# Altium schematic sheet connectors.SchDoc(connectors)
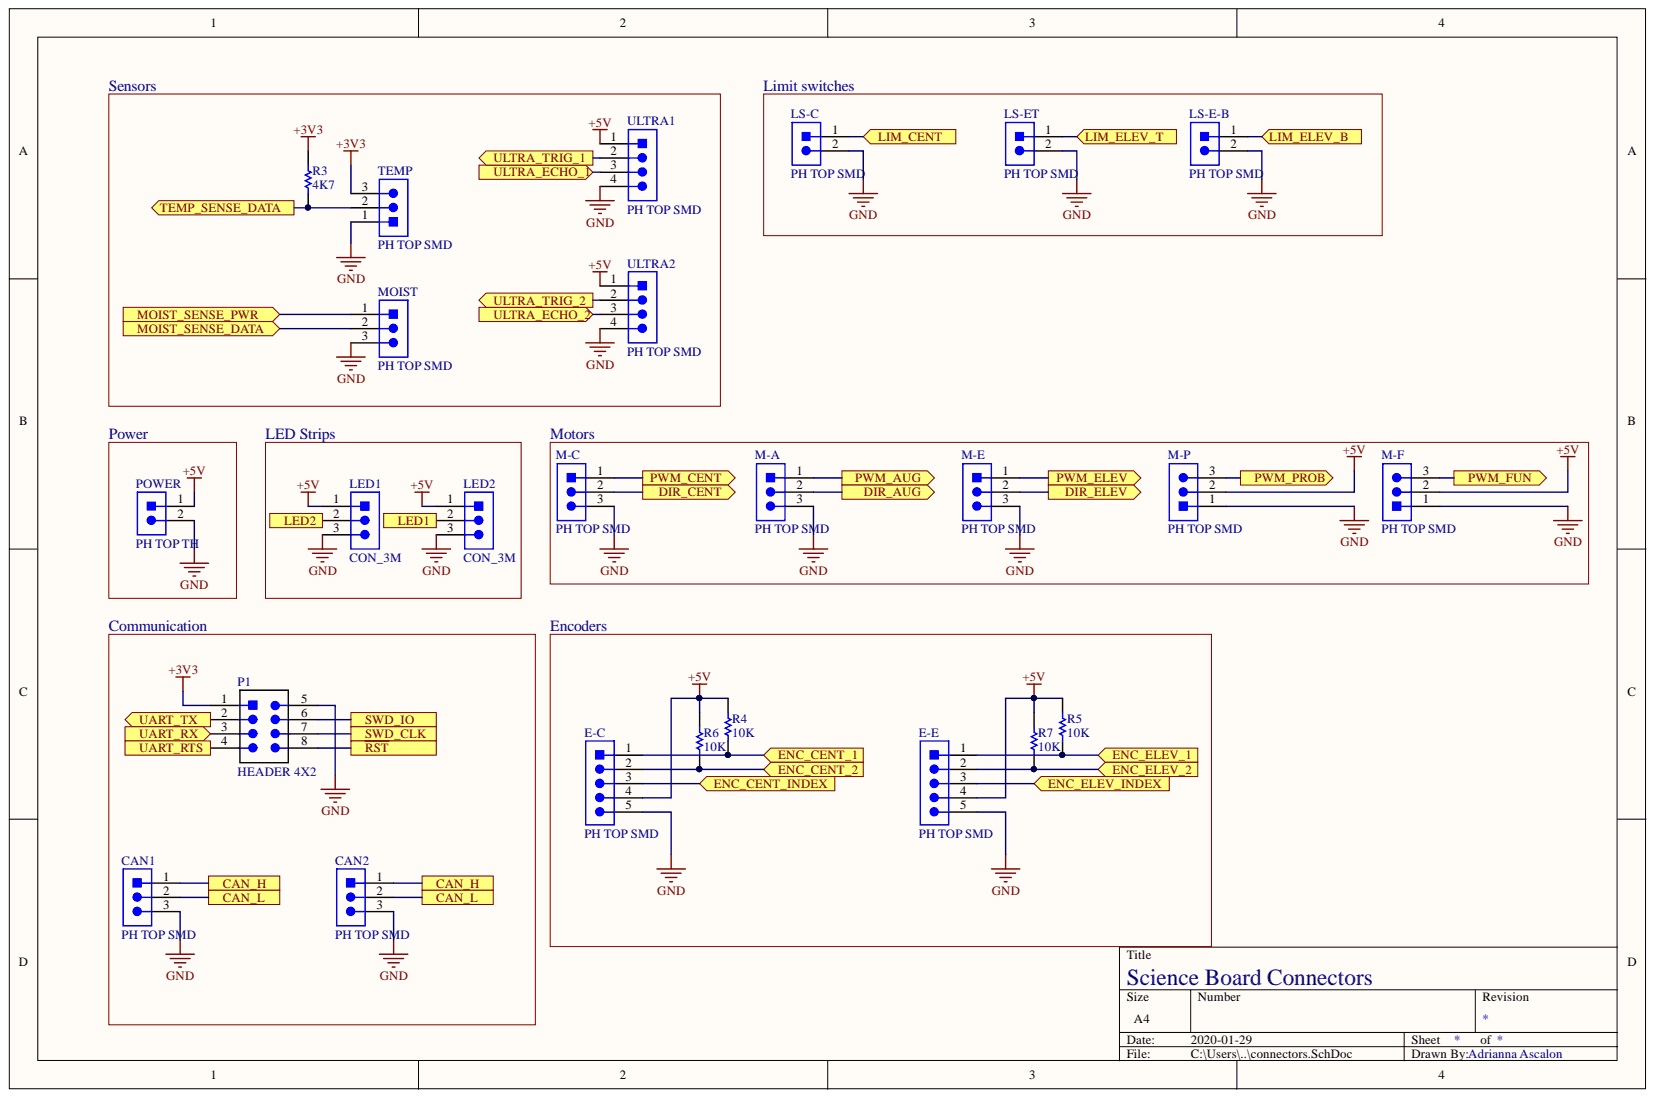
[diagram p0: connectors.SchDoc]

## Parts
CAN1  PH TOP SMD  [JST-B3B-SM4-TB]
CAN2  PH TOP SMD  [JST-B3B-SM4-TB]
E-C  PH TOP SMD  [JST-B5B-SM4-TB]
E-E  PH TOP SMD  [JST-B5B-SM4-TB]
LED1  CON_3M  [JST-B3B-SM4-TB]
LED2  CON_3M  [JST-B3B-SM4-TB]
LS-C  PH TOP SMD  [JST-B2B-SM4-TB]
LS-E-B  PH TOP SMD  [JST-B2B-SM4-TB]
LS-ET  PH TOP SMD  [JST-B2B-SM4-TB]
M-A  PH TOP SMD  [JST-B3B-SM4-TB]
M-C  PH TOP SMD  [JST-B3B-SM4-TB]
M-E  PH TOP SMD  [JST-B3B-SM4-TB]
M-F  PH TOP SMD  [JST-B3B-SM4-TB]
M-P  PH TOP SMD  [JST-B3B-SM4-TB]
MOIST  PH TOP SMD  [JST-B3B-SM4-TB]
P1  HEADER 4X2  [HEADER_2X4]
POWER  PH TOP TH  [JST-B2B-PHSK]
R3  value 4K7  [RESC0603(1608)_N]  (hidden comment: RES_4K7_0603)
R4  value 10K  [RESC0603(1608)_N]  (hidden comment: RES_10K_0603)
R5  value 10K  [RESC0603(1608)_N]  (hidden comment: RES_10K_0603)
R6  value 10K  [RESC0603(1608)_N]  (hidden comment: RES_10K_0603)
R7  value 10K  [RESC0603(1608)_N]  (hidden comment: RES_10K_0603)
TEMP  PH TOP SMD  [JST-B3B-SM4-TB]
ULTRA1  PH TOP SMD  [JST-B4B-SM4-TB]
ULTRA2  PH TOP SMD  [JST-B4B-SM4-TB]

## Ports
CAN_H, CAN_L, DIR_AUG, DIR_CENT, DIR_ELEV, ENC_CENT_1, ENC_CENT_2, ENC_CENT_INDEX, ENC_ELEV_1, ENC_ELEV_2, ENC_ELEV_INDEX, LED1, LED2, LIM_CENT, LIM_ELEV_B, LIM_ELEV_T, MOIST_SENSE_DATA, MOIST_SENSE_PWR, PWM_AUG, PWM_CENT, PWM_ELEV, PWM_FUN, PWM_PROB, SWD_CLK, SWD_IO, TEMP_SENSE_DATA, UART_RTS, UART_RX, UART_TX, ULTRA_ECHO_1, ULTRA_ECHO_2, ULTRA_TRIG_1, ULTRA_TRIG_2, ~{RST}

## Nets
+3V3: P1.1 R3.2 TEMP.3
+5V: E-C.4 E-E.4 LED1.1 LED2.1 M-F.2 M-P.2 POWER.1 R4.2 R5.2 R6.2 R7.2 ULTRA1.1 ULTRA2.1
GND: CAN1.3 CAN2.3 E-C.5 E-E.5 LED1.3 LED2.3 LS-C.2 LS-E-B.2 LS-ET.2 M-A.3 M-C.3 M-E.3 M-F.1 M-P.1 MOIST.3 P1.5 POWER.2 TEMP.1 ULTRA1.4 ULTRA2.4
~{RST}: P1.8 ; port ~{RST}
CAN_H: CAN1.1 CAN2.1 ; port CAN_H
CAN_L: CAN1.2 CAN2.2 ; port CAN_L
DIR_AUG: M-A.2 ; port DIR_AUG
DIR_CENT: M-C.2 ; port DIR_CENT
DIR_ELEV: M-E.2 ; port DIR_ELEV
ENC_CENT_1: E-C.1 R4.1 ; port ENC_CENT_1
ENC_CENT_2: E-C.2 R6.1 ; port ENC_CENT_2
ENC_CENT_INDEX: E-C.3 ; port ENC_CENT_INDEX
ENC_ELEV_1: E-E.1 R5.1 ; port ENC_ELEV_1
ENC_ELEV_2: E-E.2 R7.1 ; port ENC_ELEV_2
ENC_ELEV_INDEX: E-E.3 ; port ENC_ELEV_INDEX
LED1: LED2.2 ; port LED1
LED2: LED1.2 ; port LED2
LIM_CENT: LS-C.1 ; port LIM_CENT
LIM_ELEV_B: LS-E-B.1 ; port LIM_ELEV_B
LIM_ELEV_T: LS-ET.1 ; port LIM_ELEV_T
MOIST_SENSE_DATA: MOIST.2 ; port MOIST_SENSE_DATA
MOIST_SENSE_PWR: MOIST.1 ; port MOIST_SENSE_PWR
PWM_AUG: M-A.1 ; port PWM_AUG
PWM_CENT: M-C.1 ; port PWM_CENT
PWM_ELEV: M-E.1 ; port PWM_ELEV
PWM_FUN: M-F.3 ; port PWM_FUN
PWM_PROB: M-P.3 ; port PWM_PROB
SWD_CLK: P1.7 ; port SWD_CLK
SWD_IO: P1.6 ; port SWD_IO
TEMP_SENSE_DATA: R3.1 TEMP.2 ; port TEMP_SENSE_DATA
UART_RTS: P1.4 ; port UART_RTS
UART_RX: P1.3 ; port UART_RX
UART_TX: P1.2 ; port UART_TX
ULTRA_ECHO_1: ULTRA1.3 ; port ULTRA_ECHO_1
ULTRA_ECHO_2: ULTRA2.3 ; port ULTRA_ECHO_2
ULTRA_TRIG_1: ULTRA1.2 ; port ULTRA_TRIG_1
ULTRA_TRIG_2: ULTRA2.2 ; port ULTRA_TRIG_2

## Library data of the parts
CAN1 CAN2 M-A M-C M-E M-F M-P MOIST TEMP = CON_3M_PH_TOP_SMT: CONN HEADER PH TOP 3POS 2MM SMD; Part Number: B3B-PH-SM4-TB; Manufacturer: JST; ComponentLink1URL: http://www.jst-mfg.com/product/pdf/eng/ePH.pdf; ComponentLink1Description: Datasheet; Component Kind: Standard; Library Name: UWRT_Schematic_Library_2019.SchLib
E-C E-E = CON_5M_PH_TOP_SMT: CONN HEADER PH TOP 5POS 2MM SMD; Part Number: B5B-PH-SM4-TB; Manufacturer: JST; ComponentLink1URL: http://www.jst-mfg.com/product/pdf/eng/ePH.pdf; ComponentLink1Description: Datasheet; Component Kind: Standard; Library Name: UWRT_Schematic_Library_2019.SchLib
LS-C LS-E-B LS-ET = CON_2M_PH_TOP_SMT: CONN HEADER PH TOP 2POS 2MM SMD; Part Number: B2B-PH-SM4-TB; Manufacturer: JST; ComponentLink1URL: http://www.jst-mfg.com/product/pdf/eng/ePH.pdf; ComponentLink1Description: Datasheet; Component Kind: Standard; Library Name: UWRT_Schematic_Library_2019.SchLib
P1 = HEADER 4X2: Header, 4-Pin Dual row; MPN: GRPB042VWVN-RC; Manufacturer: Sullins Connector Solutions; Signal Integrity: Connector; Digikey Part Number: S9015E-04-ND; Component Kind: Standard; Library Name: UWRT_Schematic_Library_2019.SchLib
POWER = CON_2M_PH_TOP_TH: CONN HEADER PH TOP 2POS 2MM; Part Number: B2B-PH-K-S; Manufacturer: JST; ComponentLink1URL: http://www.jst-mfg.com/product/pdf/eng/ePH.pdf; ComponentLink1Description: Datasheet; Component Kind: Standard; Library Name: UWRT_Schematic_Library_2019.SchLib
R3 = RES_4K7_0603: RES SMD 4.7K OHM 5% 1/10W 0603; MPN: RC0603JR-074K7L; Manufacturer: Yageo; Tolerance: 5%; Simulation: RESISTOR; Signal Integrity: Res; Package: 0603 (1608 Metric); Dimensions: 0.063" L x 0.031" W (1.60mm x 0.80mm); Digikey Part Number: 311-4.7KGRCT-ND; Component Kind: Standard; Library Name: UWRT_Schematic_Library_2019.SchLib
R4-R7 = RES_10K_0603: RES SMD 10K OHM 5% 1/10W 0603; MPN: RC0603JR-0710KL; Manufacturer: Yageo; Tolerance: 5%; Simulation: RESISTOR; Signal Integrity: Res; Package: 0603 (1608 Metric); Dimensions: 0.063" L x 0.031" W (1.60mm x 0.80mm); Digikey Part Number: 311-10KGRCT-ND; Component Kind: Standard; Library Name: UWRT_Schematic_Library_2019.SchLib
ULTRA1 ULTRA2 = CON_4M_PH_TOP_SMT: CONN HEADER PH TOP 4POS 2MM SMD; Part Number: B4B-PH-SM4-TB; Manufacturer: JST; ComponentLink1URL: http://www.jst-mfg.com/product/pdf/eng/ePH.pdf; ComponentLink1Description: Datasheet; Component Kind: Standard; Library Name: UWRT_Schematic_Library_2019.SchLib
LED1 LED2 = CON_3M: Component Kind: Standard; Library Name: UWRT_Schematic_Library_2019.SchLib
